# Altium schematic sheet lv_branch_24v.SchDoc
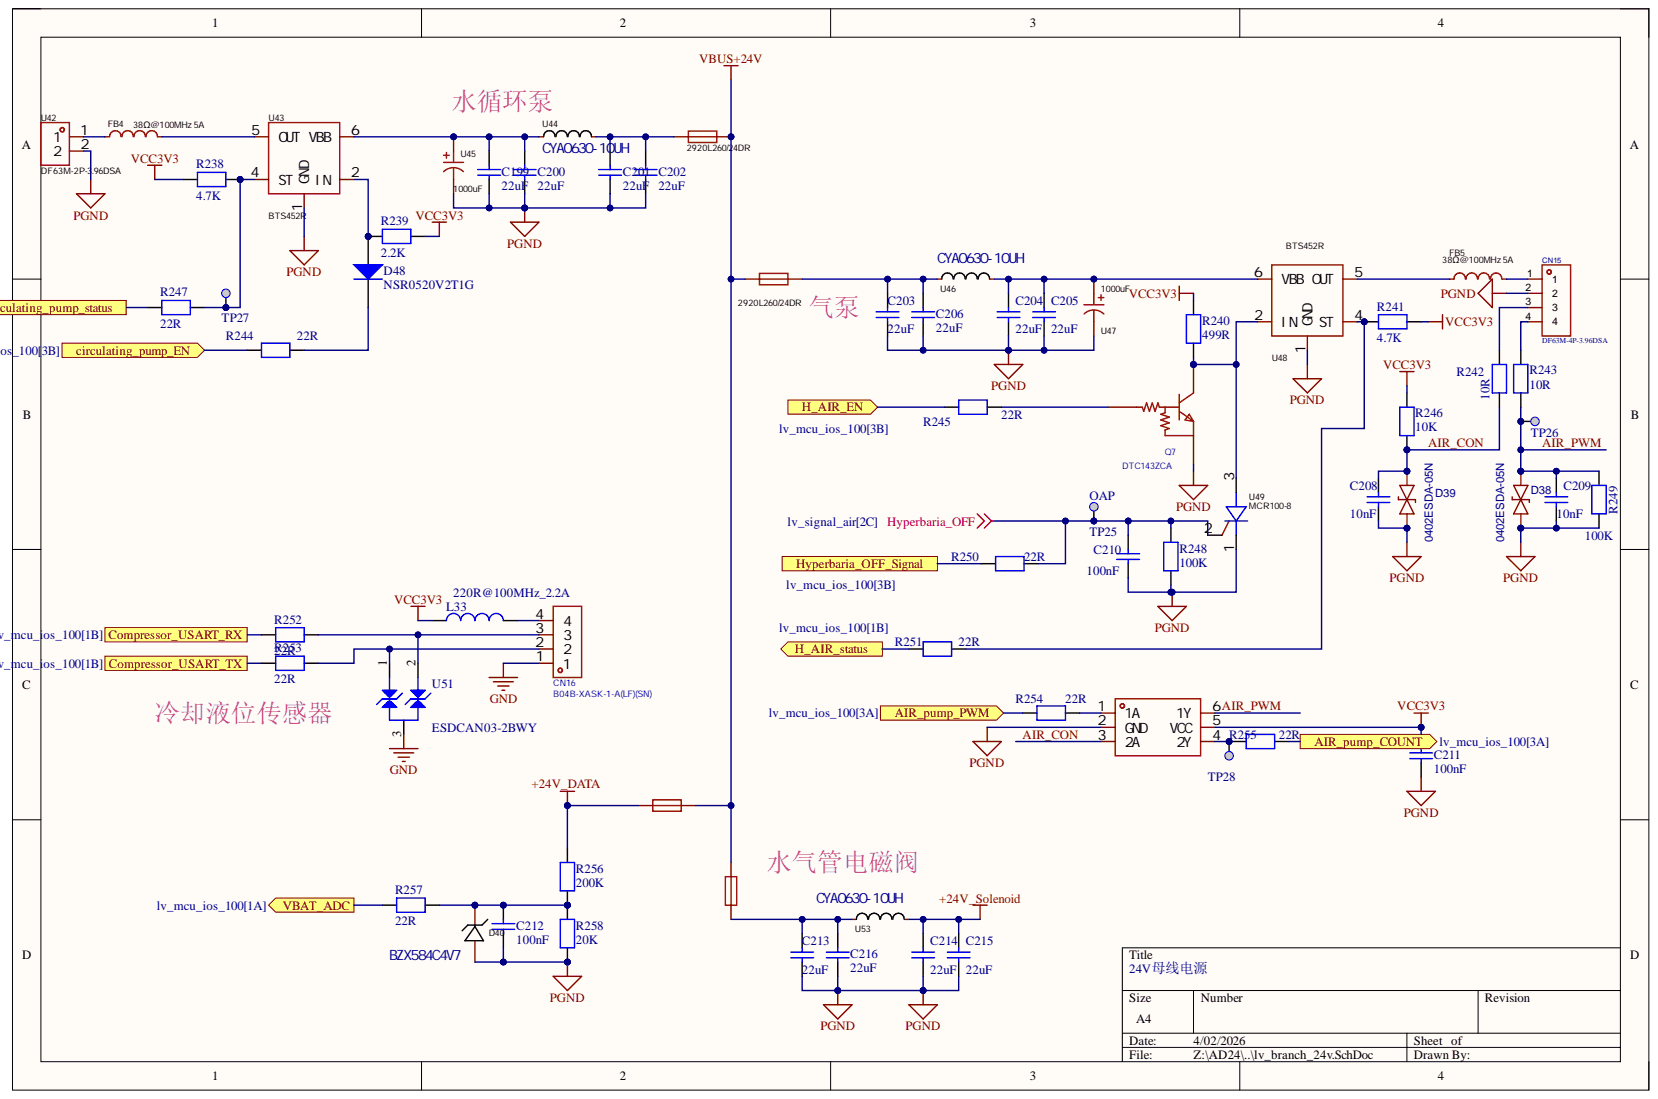
[diagram p0: lv_branch_24v.SchDoc]

## Parts
C199  22uF  [C1206]
C200  22uF  [C1206]
C201  22uF  [C1206]
C202  22uF  [C1206]
C203  22uF  [C1206]
C204  22uF  [C1206]
C205  22uF  [C1206]
C206  22uF  [C1206]
C208  10nF  [C0402]
C209  10nF  [C0402]
C210  100nF  [C0402]
C211  100nF  [C0402]
C212  100nF  [C0402]
C213  22uF  [C1206]
C214  22uF  [C1206]
C215  22uF  [C1206]
C216  22uF  [C1206]
CN15  DF63M-4P-3.96DSA  [CONN-TH_4P-P3.96_HRS_DF63M-4P-3.96DSA]
CN16  B04B-XASK-1-A(LF)(SN)  [CONN-TH_B04B-XASK-1-A-LF-SN]
D38  0402ESDA-05N  [D0402-BI]
D39  0402ESDA-05N  [D0402-BI]
D40  BZX584C4V7  [SOD-523F_L1.2-W0.8-LS1.7-RD]
D48  NSR0520V2T1G  [SOD-523F_L1.2-W0.8-LS1.7-RD]
F2  2920L260/24DR  [F2920]
F3  2920L260/24DR  [F2920]
F4  2920L260/24DR  [F2920]
F5  2920L260/24DR  [F2920]
FB4  PZ3216D380-5R0TF  [L1206]  (value not drawn)
FB5  LCSC Part Name: 38Ω@100MHz 5A  [L1206]  (hidden comment: PZ3216D380-5R0TF)
L33  220R@100MHz_2.2A  [L0603]
Q7  DTC143ZCA  [SOT-416_L1.6-W0.8-P1.00-LS1.6-BR]
R238  4.7K  [R0402]
R239  2.2K  [R0603]
R240  499R  [R0603]
R241  4.7K  [R0402]
R242  10R  [R0603]
R243  10R  [R0603]
R244  22R  [R0402]
R245  22R  [R0402]
R246  10K  [R0603]
R247  22R  [R0402]
R248  100K  [R0402]
R249  100K  [R0603]
R250  22R  [R0402]
R251  22R  [R0402]
R252  22R  [R0402]
R253  22R  [R0402]
R254  22R  [R0402]
R255  22R  [R0402]
R256  200K  [R0603]
R257  22R  [R0402]
R258  20K  [R0603]
TP25  OAP  [TP_SMD_1MM]  (no BOM)
TP26  Test Point  [TP_SMD_1MM]  (value not drawn)  (no BOM)
TP27  Test Point  [TP_SMD_1MM]  (value not drawn)  (no BOM)
TP28  Test Point  [TP_SMD_1MM]  (value not drawn)  (no BOM)
U42  DF63M-2P-3.96DSA  [CONN-TH_2P-P3.96_DF63M-2P-3.96DSA]
U43  BTS452R  [TO-252-5_L6.5-W6.2-P1.14-LS10.0-TL]
U44  CYA0630-10UH  [IND-SMD_L7.2-W6.6_GPSR07X0]
U45  1000uF  [CAP-TH_BD10.0-P5.00-D0.6-FD]
U46  CYA0630-10UH  [IND-SMD_L7.2-W6.6_GPSR07X0]
U47  1000uF  [CAP-TH_BD10.0-P5.00-D0.6-FD]
U48  BTS452R  [TO-252-5_L6.5-W6.2-P1.14-LS10.0-TL]
U49  MCR100-8  [SOT-23-3_L2.9-W1.3-P1.90-LS2.4-BR]
U51  ESDCAN03-2BWY  [DIO_SOT323]
U52  74LVC2G17GW,125  [SOT-363_L2.0-W1.3-P0.65-LS2.1-BR]  (value not drawn)
U53  CYA0630-10UH  [IND-SMD_L7.2-W6.6_GPSR07X0]

## Ports
AIR_pump_COUNT, AIR_pump_PWM, circulating_pump_EN, circulating_pump_status, Compressor_USART_RX, Compressor_USART_TX, H_AIR_EN, H_AIR_status, Hyperbaria_OFF_Signal, VBAT_ADC

## Nets
+24V_DATA: F4.2 R256.1
+24V_Solenoid: C214.2 C215.2 U53.2
GND: CN16.1 U51.3
Hyperbaria_OFF: C210.1 R248.2 R250.1 TP25.1 U49.2
PGND: C199.1 C200.1 C201.1 C202.1 C203.1 C204.1 C205.1 C206.1 C208.1 C209.1 C210.2 C211.1 C212.1 C213.1 C214.1 C215.1 C216.1 CN15.2 D38.1 D39.1 D40.2 Q7.2 R248.1 R249.1 R258.2 U42.2 U43.1(GND) U45.2 U47.2 U48.1(GND) U49.1 U52.2(GND)
VBUS+24V: F2.1 F3.1 F4.1 F5.1
VCC3V3: C211.2 L33.2 R238.2 R239.1 R240.1 R241.2 R246.2 U52.5(VCC)
AIR_CON: C208.2 D39.2 R242.2 R246.1 U52.3(2A)
AIR_pump_COUNT: R255.1 ; port AIR_pump_COUNT
AIR_pump_PWM: R254.1 ; port AIR_pump_PWM
AIR_PWM: C209.2 D38.2 R243.2 R249.2 TP26.1 U52.6(1Y)
circulating_pump_EN: R244.2 ; port circulating_pump_EN
circulating_pump_status: R247.2 ; port circulating_pump_status
Compressor_USART_RX: R252.1 ; port Compressor_USART_RX
Compressor_USART_TX: R253.1 ; port Compressor_USART_TX
H_AIR_EN: R245.1 ; port H_AIR_EN
H_AIR_status: R251.1 ; port H_AIR_status
Hyperbaria_OFF_Signal: R250.2 ; port Hyperbaria_OFF_Signal
VBAT_ADC: R257.1 ; port VBAT_ADC
NetC199_2: C199.2 C200.2 U43.6(VBB) U44.2 U45.1
NetC201_2: C201.2 C202.2 F2.2 U44.1
NetC203_2: C203.2 C206.2 F3.2 U46.1
NetC204_2: C204.2 C205.2 U46.2 U47.1 U48.6(VBB)
NetC212_2: C212.2 D40.1 R256.2 R257.2 R258.1
NetC213_2: C213.2 C216.2 F5.2 U53.1
NetCN15_1: CN15.1 FB5.2
NetCN15_3: CN15.3 R242.1
NetCN15_4: CN15.4 R243.1
NetCN16_2: CN16.2 R253.2 U51.1
NetCN16_3: CN16.3 R252.2 U51.2
NetCN16_4: CN16.4 L33.1
NetD48_1: D48.1 R244.1
NetD48_2: D48.2 R239.2 U43.2(IN)
NetFB4_1: FB4.1 U43.5(OUT)
NetFB4_2: FB4.2 U42.1
NetFB5_1: FB5.1 U48.5(OUT)
NetQ7_1: Q7.1 R245.2
NetQ7_3: Q7.3 R240.2 U48.2(IN) U49.3
NetR238_1: R238.1 R247.1 TP27.1 U43.4(ST)
NetR241_1: R241.1 R251.2 U48.4(ST)
NetR254_2: R254.2 U52.1(1A)
NetR255_2: R255.2 TP28.1 U52.4(2Y)

## Library data of the parts
C199-C206 C213-C216 = Capacitor_2: 35V, X5R, 10%; Manufacturer: TDK; Manufacturer_Part_Number: C3216X5R1V226MTJ00E; Component Kind: Standard; ComponentLink1Description: Datasheet; ComponentLink1URL: http://tunmcdsvn.tun.st.com/Component_Datasheet_Library/Trunk/Capacitors/Yageo_Multilayer_capacitor_X5R_series_100pF_to_100uF; Library Name: HiPin_Library_AD.IntLib
C208-C212 = Capacitor: 50V, 10%, X7R; Internal_Part_Number: MCD-00003; Manufacturer: muRata; Manufacturer_Part_Number: GRM155R71H104KE14D; Component Kind: Standard; ComponentLink1Description: Datasheet; ComponentLink1URL: http://tunmcdsvn.tun.st.com/Component_Datasheet_Library/Trunk/Capacitors/Yageo_Capacitor_NP0_16V-to-50V; Library Name: HiPin_Library_AD.IntLib; Package: C0402
  C208 C209: Value: 10nF
  C210-C212: Value: 100nF
CN16 = B04B-XASK-1-A(LF)(SN): 插座XA2.5mm,3A,H8.8mm; Manufacturer: JST; Add into BOM: yes; Flame Retardant Rating: UL94V-0; Number of PINs Per Row: 4; Pins Structure: 1x4P; Pitch: 2.5mm; Plastic Material: 玻纤增强PA66; Rated Voltage (Max): 250V; Reference Series: XA; Supplementary Features: 带柱；打K脚; X-Length of Bottom Edge on Board (Spacing Line): 12.5mm; Y-Width of Bottom Edge on Board: 6.4mm; Z-Height of the Board: 8.8mm; Colour: 白色; Component Kind: Standard; Contact Material: 铜合金; Contact Plating: 锡; Convert to PCB: yes; Current Rating (Max): 3A; Datasheet: https://atta.szlcsc.com/upload/public/pdf/source/20200416/C514162_2DCD7D1CD2019D14145B8FEA1B6236C2.pdf; Device: B04B-XASK-1-A(LF)(SN); JLCPCB Part Class: Extended Part; LCSC Part Name: 1x4P 间距:2.5mm 直插 系列:XA; Library Name: HiPin_Library_AD.IntLib; Manufacturer Part: B04B-XASK-1-A(LF)(SN); Mounting Style: 直插; Number of Pins: 4P; Number of Rows: 1; Operating Temperature Range: -25℃~+85℃; PCB3D: CONN-TH_B04B-XASK-1-A-LF-SN; Row Spacing: -; Supplier: LCSC; Supplier Footprint: 插件,P=2.5mm; Supplier Part: C491709; Symbol: B04B-XASK-1-A(LF)(SN)
D38 D39 = 0402ESDA-05N: BOM_Manufacturer: Bourne Semicon (Shenzhen); Contributor: LCSC; BOM_JLCPCB Part Class: Extended Part; BOM_Manufacturer Part: 0402ESDA-05N; BOM_Supplier: LCSC; BOM_Supplier Part: C316049; Component Kind: Standard; Library Name: HiPin_Library_AD.IntLib; spicePre: D; spiceSymbolName: 0402ESDA-05N
D40 = LM5Z3V6T1G: 4.8~5.4V, 200mW; Power Dissipation: 500mW; Component Kind: Standard; Library Name: HiPin_Library_AD.IntLib; Manufacturer Part: BZX584C4V7; Supplier Footprint: SOD-123; Tolerance: -
D48 = 1N4148: Component Kind: Standard; Library Name: HiPin_Library_AD.IntLib
F2-F5 = 2920L260/24DR: Manufacturer: Littelfuse(美国力特); Add into BOM: yes; Current Rating (Max): 40A; Hold Current: 2.6A; Maximum Time to Trip: 10s; Operating Voltage (Max): 24V; Resistance - Initial (Ri) (Min): 25mΩ; Resistance - Post Trip (R1) (Max): 75mΩ; Trip Current: 5A; Component Kind: Standard; Convert to PCB: yes; Datasheet: https://atta.szlcsc.com/upload/public/pdf/source/20181031/C315905_4DA816BF3BC9EA6CE3926B09DB032E96.pdf; Device: 2920L260/24DR; JLCPCB Part Class: Extended Part; LCSC Part Name: 24V 2.6A 2920自恢复; Library Name: HiPin_Library_AD.IntLib; Manufacturer Part: 2920L260/24DR; Operating Temperature: -40℃~+85℃; Power Dissipation: -; Supplier: LCSC; Supplier Footprint: 2920; Supplier Part: C315904; Symbol: 2920L260/24DR
FB4 FB5 = BLM31PG601SN1L: Manufacturer: Sunlord(顺络); Add into BOM: yes; Circuits: 1; Current Rating: 1.5A; DC Resistance: 80mΩ; Impedance @ Frequency: 600Ω@100MHz; Tolerance: ±25%; Component Kind: Standard; Convert to PCB: yes; Datasheet: https://atta.szlcsc.com/upload/public/pdf/source/20180326/C88997_FE7208E239BA92CF7577D85CF2703D30.pdf; Device: BLM31PG601SN1L; JLCPCB Part Class: Extended Part; Library Name: HiPin_Library_AD.IntLib; Manufacturer Part: PZ3216D380-5R0TF; Supplier: LCSC; Supplier Footprint: 1206; Supplier Part: C16902; Symbol: BLM31PG601SN1L
  FB4: LCSC Part Name: 38Ω@100MHz 5A
L33 = MCD-00047: SMD Chip ferrite bead, 220R@100MHz +/-25%,2.2A, 50mohm; Manufacturer: Sunlord; Manufacturer_Part_Number: UPZ1608U221-2R2TF; Database Table Name: MCD_PARTS$; Component Kind: Standard; ComponentLink1Description: Datasheet; ComponentLink1URL: http://tunmcdsvn.tun.st.com/Component_Datasheet_Library/Trunk/Inductors/TaiTech_Bead_FCM1608_series; Internal_Part_Number: MCD-00047; Library Name: MCD_PARTS_database.DbLib
Q7 = DTC043ZEBTL: NPN 三极管; Manufacturer: ROHM(罗姆); Add into BOM: yes; Collector Current (Ic): 100mA; Collector-Emitter Breakdown Voltage (Vceo): 50V; DC Current Gain (hFE@Ic,Vce): 80@5mA,10V; Input Resistor: 4.7kΩ; Input Voltage (VI(on)@Ic,Vce): 1.1V@5mA,0.3V; Output Voltage (VO(on)@Io/Ii): 150mV@5mA,0.5mA; Power Dissipation (Pd): 150mW; Resistor Ratio: 10; Component Kind: Standard; Convert to PCB: yes; Datasheet: https://atta.szlcsc.com/upload/public/pdf/source/20230825/AFF9D3CF4DD067520C5D7C04408FCBF2.pdf; Device: DTC043ZEBTL; JLCPCB Part Class: Extended Part; LCSC Part Name: 耐压:50V 电流:100mA; Library Name: HiPin_Library_AD.IntLib; Manufacturer Part: DTC043ZEBTL; Operating Temperature: -55℃~+150℃; PCB3D: SOT-416-3P_L1.6-W0.8-H0.9-LS1.6-P1.00; Supplier: LCSC; Supplier Footprint: SOT-416FL; Supplier Part: C96459; Symbol: DTC043ZEBTL; Transistor Type: -
R238-R245 R247-R258 = RES: 1%; Value: 10K; Component Kind: Standard; Library Name: HiPin_Library_AD.IntLib
R246 = RES: 1%; Component Kind: Standard; Library Name: HiPin_Library_AD.IntLib; Value: 10K
TP25-TP28 = MCD-00074: Test Point 1mm; Part_Type: Mechanical; Database Table Name: MCD_PARTS$; ComponentLink1Description: Datasheet; ComponentLink1URL: http://na; ECCN_EU: n/a; ECCN_US: n/a; Internal_Part_Number: MCD-00074; Library Name: MCD_PARTS_database.DbLib; Manufacturer: n/a; Manufacturer_Part_Number: n/a; Provided_By: n/a; Status: Released
U42 = DF63M-2P-3.96DSA: Manufacturer: HRS(广濑); Add into BOM: yes; Component Kind: Standard; Convert to PCB: yes; Datasheet: https://atta.szlcsc.com/upload/public/pdf/source/20221205/6AC71532B9B9566170A63CE16B2C46ED.pdf; Device: DF63M-2P-3.96DSA; JLCPCB Part Class: Extended Part; LCSC Part Name: DF63M-2P-3.96DSA; Library Name: HiPin_Library_AD.IntLib; Manufacturer Part: DF63M-2P-3.96DSA; Supplier: LCSC; Supplier Footprint: 插件,P=3.96mm; Supplier Part: C5254350; Symbol: DF63M-2P-3.96DSA
U43 U48 = BTS452R_C126924: Manufacturer: Infineon(英飞凌); Add into BOM: yes; Component Kind: Standard; Convert to PCB: yes; Datasheet: https://atta.szlcsc.com/upload/public/pdf/source/20190419/C386345_DBEE7F1C619255E05785D0D1BACFA6FB.pdf; Device: BTS452R_C126924; JLCPCB Part Class: Extended Part; LCSC Part Name: BTS452R; Library Name: HiPin_Library_AD.IntLib; Manufacturer Part: BTS452R; Supplier: LCSC; Supplier Footprint: TO-252-4; Supplier Part: C126924; Symbol: BTS452R
U44 U46 U53 = CYA0630-10UH: Manufacturer: SHOU HAN(首韩); Add into BOM: yes; DC Resistance (DCR): 71mΩ; Name: 10uH; Rated Current: 4A; Tolerance: ±20%; Value: 10uH; Component Kind: Standard; Convert to PCB: yes; Datasheet: https://atta.szlcsc.com/upload/public/pdf/source/20221010/B55E8796F05F85A38044F3826C14EFDD.pdf; Device: CYA0630-10UH; JLCPCB Part Class: Extended Part; LCSC Part Name: 10uH ±20%; Library Name: HiPin_Library_AD.IntLib; Manufacturer Part: CYA0630-10UH; PCB3D: IND-SMD_L7.2-W6.6-H3.0_GPSR07X0; Supplier: LCSC; Supplier Footprint: SMD,6.6x7.2mm; Supplier Part: C5189958; Symbol: CYA0630-10UH
U45 U47 = HG1V108M1016PC: Manufacturer: HONOR(荣誉); Add into BOM: yes; Equivalent Series Resistance(ESR): 28mΩ; L: 16mm; Lifetime @ Temp: 2000hrs@105℃; Rated Voltage: 35V; Ripple Current: 4.2A; Tolerance: ±20%; φD: 10mm; Component Kind: Standard; Convert to PCB: yes; Datasheet: https://atta.szlcsc.com/upload/public/pdf/source/20230323/E6B46A248FBB182638B68380B3289E6D.pdf; Device: HG1V108M1016PC; JLCPCB Part Class: Extended Part; LCSC Part Name: 1000uF ±20% 35V; Lead Spacing: 5mm; Library Name: HiPin_Library_AD.IntLib; Manufacturer Part: HG1V108M1016PC; Operating Temperature: -55℃~+105℃; Supplier: LCSC; Supplier Footprint: 插件,D10xL16mm; Supplier Part: C5438864; Symbol: HG1V108M1016PC; Value: 1000uF
U49 = MCR100-8_C5184437: Manufacturer: HXY MOSFET(华轩阳电子); Add into BOM: yes; Average Gate Power Dissipation (PG(AV)): 100mW; Gate Trigger   Voltage (Vgt): 800mV; Gate Trigger Current(Igt): 60uA; Holding Current (Ih): 5mA; Peak non-repetitive surge current (Itsm@f): 8A; Peak Repetitive Off?State Voltage (Vdrm): 600V; RMS On-State Current(It (rms)): 800mA; SCR Type: 单向可控硅; Voltage - On State (Vtm): 1.7V; Component Kind: Standard; Convert to PCB: yes; Datasheet: https://atta.szlcsc.com/upload/public/pdf/source/20240902/7358CD860A43FFBE3B53FCDEB35A6F8C.pdf; Device: MCR100-8_C5184437; JLCPCB Part Class: Extended Part; LCSC Part Name: 单向 Vgt: 800mV IT:0.8A Vdrm: 600V; Library Name: HiPin_Library_AD.IntLib; Manufacturer Part: MCR100-8; Operating Temperature: -; PCB3D: SOT-23-3_L2.9-W1.3-P1.90-LS2.4-BR-CW; Supplier: LCSC; Supplier Footprint: SOT-23; Supplier Part: C5184437; Symbol: MCR100-8_C5184437
U51 = MCD-01228: 极性  双向  反向截止电压(Vrwm)  24V  钳位电压  41V  峰值脉冲电流(Ipp)  3.7A@8/20us; Manufacturer: STMICROELECTRONICS; Database Table Name: MCD_PARTS$; Component Kind: Standard; ComponentLink1Description: Datasheet; ComponentLink1URL: http://tunmcdsvn.tun.st.com/Component_Datasheet_Library/Trunk/Diodes/STM_ESD_ESDCAN02-2BWY; Library Name: MCD_PARTS_database.DbLib; Manufacturer_Part_Number: ESDCAN03-2BWY
U52 = 74LVC2G17GW,125: 2路斯密特触发器; Manufacturer: Nexperia(安世); Add into BOM: yes; Current - Output High(IOH): 32mA; Input type: 施密特触发器; Logic Family: 74LVC; Number of Bits per Element: 1; Number of Elements: 2; output type: 推挽; Voltage - Supply: 1.65V~5.5V; Component Kind: Standard; Convert to PCB: yes; Current - Output Low(IOL): 32mA; Datasheet: https://atta.szlcsc.com/upload/public/pdf/source/20240724/F8BC06F6786231A20F016105A2EDA3EF.pdf; Device: 74LVC2G17GW,125; JLCPCB Part Class: Extended Part; LCSC Part Name: 74LVC2G17GW,125; Library Name: HiPin_Library_AD.IntLib; Manufacturer Part: 74LVC2G17GW,125; Operating Temperature: -40℃~+125℃; PCB3D: SOT-363-6P_L2.0-W1.3-H1.1-LS2.0-P0.65; Supplier: LCSC; Supplier Footprint: SOT-363; Supplier Part: C80500; Symbol: 74LVC2G17GW,125
CN15 = DF63M-4P-3.96DSA: Component Kind: Standard; Library Name: HiPin_Library_AD.IntLib; Symbol: DF63M-4P-3.96DSA
